# Altium schematic sheet Sheet2.SchDoc
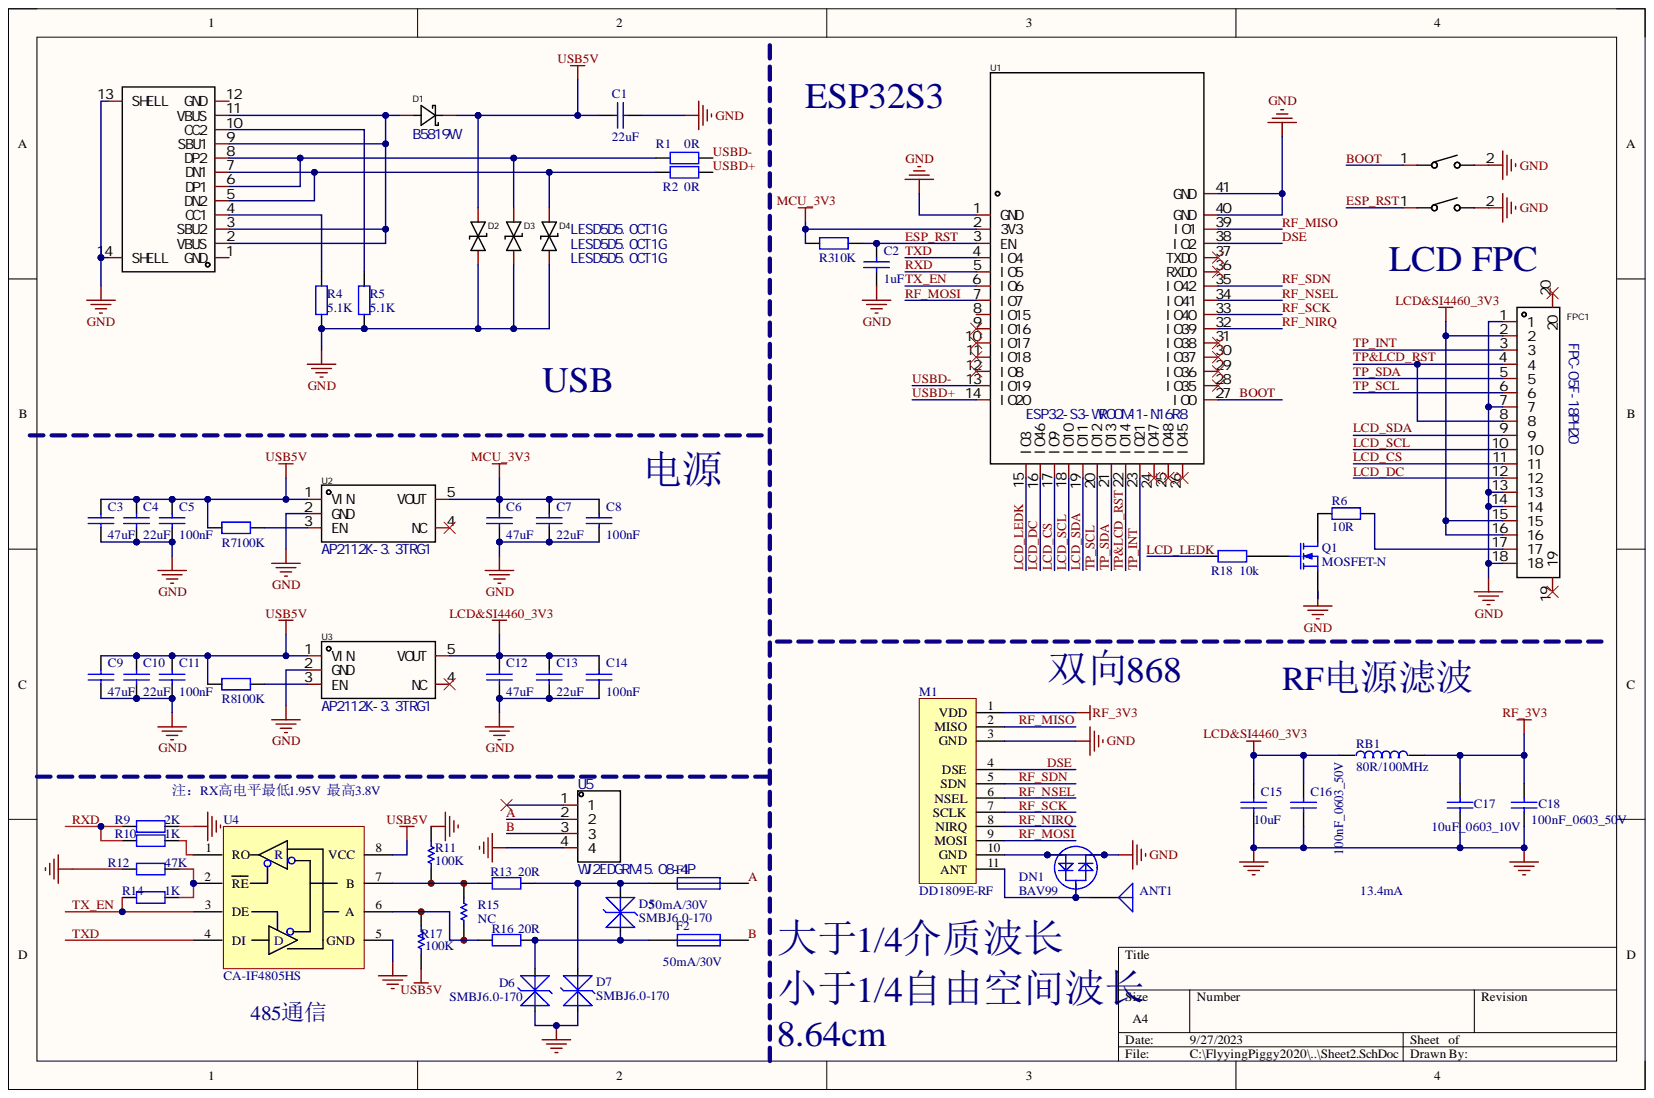
[diagram p0: Sheet2.SchDoc]

## Parts
ANT1  (no value)  [18AWG]
C1  22uF  [0603_C]
C2  1uF  [0603_C]
C3  47uF  [0603_C]
C4  22uF  [0603_C]
C5  100nF  [0603_C]
C6  47uF  [0603_C]
C7  22uF  [0603_C]
C8  100nF  [0603_C]
C9  47uF  [0603_C]
C10  22uF  [0603_C]
C11  100nF  [0603_C]
C12  47uF  [0603_C]
C13  22uF  [0603_C]
C14  100nF  [0603_C]
C15  10uF_0603_10V  value 10uF  [0603_C]
C16  100nF_0603_50V  [0603_C]
C17  10uF_0603_10V  [0603_C]
C18  100nF_0603_50V  [0603_C]
D1  B5819W  [SOD-123F_L2.7-W1.6-LS3.8-RD-1]
D2  LESD5D5.0CT1G  [SOD-523_L1.2-W0.8-LS1.6-BI]
D3  LESD5D5.0CT1G  [SOD-523_L1.2-W0.8-LS1.6-BI]
D4  LESD5D5.0CT1G  [SOD-523_L1.2-W0.8-LS1.6-BI]
D5  SMBJ6.0-170  [SMB(DO-214AA) - TVS]
D6  SMBJ6.0-170  [SMB(DO-214AA) - TVS]
D7  SMBJ6.0-170  [SMB(DO-214AA) - TVS]
DN1  BAV99  [SOT-23(SOT-23-3)]
F1  贴片自恢复保险丝 1812 0.1A 60V  [1206_R]  (value not drawn)
F2  贴片自恢复保险丝 1812 0.1A 60V  [1206_R]  (value not drawn)
FPC1  FPC-05F-18PH20  [FPC-SMD_18P-P0.50_FPC-05F-18PH20]
M1  DD1809E-RF  [DD1809(E)-RF-平]
Q1  MOSFET-N  [SOT-23(SOT-23-3)]
R1  0R  [0603_R]
R2  0R  [0603_R]
R3  value 10K  [0603_R]  (hidden comment: 0R)
R4  5.1K  [0603_R]
R5  5.1K  [0603_R]
R6  value 10R  [0603_R]  (hidden comment: 0R)
R7  100K  [0603_R]
R8  100K  [0603_R]
R9  2K  [0603_R]
R10  1K  [0603_R]
R11  100K  [0603_R]
R12  47K  [0603_R]
R13  20R  [0603_R]
R14  1K  [0603_R]
R15  NC  [0603_R]
R16  20R  [0603_R]
R17  100K  [0603_R]
R18  value 10k  [0603_R]  (hidden comment: 0R)
RB1  value 80R/100MHz  [0603_R]  (hidden comment: 80R/100MHz_0603)
SW1  TS-1088-AR02016  [SW-SMD_L3.9-W3.0-P4.45]  (value not drawn)
SW2  TS-1088-AR02016  [SW-SMD_L3.9-W3.0-P4.45]  (value not drawn)
U1  ESP32-S3-WROOM-1-N16R8  [WIRELM-SMD_ESP32-S3-WROOM-1]
U2  AP2112K-3.3TRG1  [SOT-25-5_L2.9-W1.6-P0.95-LS2.8-BL]
U3  AP2112K-3.3TRG1  [SOT-25-5_L2.9-W1.6-P0.95-LS2.8-BL]
U4  CA-IF4805HS  [SOP8]
U5  WJ2EDGRM-5.08-4P  [CONN-TH_4P-P5.08_WJ2EDGRM-5.08-4P-14-00A]
USB1  TYPE-C 16PIN 2MD(073)  [USB-C-SMD_TYPE-C-6PIN-2MD-073]  (value not drawn)

## Nets
GND: C1.2 C2.1 C3.1 C4.1 C5.1 C6.1 C7.1 C8.1 C9.1 C10.1 C11.1 C12.1 C13.1 C14.1 C15.2 C16.1 C17.1 C18.1 D2.1 D3.1 D4.1 D6.1 D7.1 DN1.1 DN1.2 FPC1.1 FPC1.7 FPC1.13 FPC1.14 FPC1.18 M1.3 M1.10 Q1.2 R4.1 R5.1 R9.1 R11.2 R12.1 SW1.2 SW2.2 U1.1 U1.40 U1.41 U2.2 U3.2 U4.5 U5.4 USB1.13 USB1.14
LCD&SI4460_3V3: C12.2 C13.2 C14.2 C15.1 C16.2 FPC1.2 FPC1.15 FPC1.16 RB1.1 U3.5
MCU_3V3: C6.2 C7.2 C8.2 R3.1 U1.2 U2.5
RF_3V3: C17.2 C18.2 M1.1 RB1.2
USB5V: C1.1 C3.2 C4.2 C5.2 C9.2 C10.2 C11.2 D1.1 D2.2 R7.1 R8.1 R17.1 U2.1 U3.1 U4.8
A: F1.2 U5.2
B: F2.2 U5.3
BOOT: SW1.1 U1.27
DSE: M1.4 U1.38
ESP_RST: C2.2 R3.2 SW2.1 U1.3
LCD_CS: FPC1.11 U1.17
LCD_DC: FPC1.12 U1.16
LCD_LEDK: R18.1 U1.15
LCD_SCL: FPC1.10 U1.18
LCD_SDA: FPC1.9 U1.19
RF_MISO: M1.2 U1.39
RF_MOSI: M1.9 U1.7
RF_NIRQ: M1.8 U1.32
RF_NSEL: M1.6 U1.34
RF_SCK: M1.7 U1.33
RF_SDN: M1.5 U1.35
RXD: R9.2 R10.1 U1.5
TP&LCD_RST: FPC1.4 FPC1.8 U1.22
TP_INT: FPC1.3 U1.23
TP_SCL: FPC1.6 U1.20
TP_SDA: FPC1.5 U1.21
TX_EN: R14.1 U1.6 U4.3
TXD: U1.4 U4.4
USBD+: R2.2 U1.14
USBD-: R1.2 U1.13
NetANT1_1: ANT1.1 DN1.3 M1.11
NetD1_2: D1.2 USB1.2 USB1.3 USB1.9 USB1.11
NetD3_2: D3.2 R1.1 USB1.6 USB1.8
NetD4_2: D4.2 R2.1 USB1.5 USB1.7
NetD5_1: D5.1 D6.2 F2.1 R16.1
NetD5_2: D5.2 D7.2 F1.1 R13.1
NetFPC1_17: FPC1.17 R6.2
NetQ1_1: Q1.1 R18.2
NetQ1_3: Q1.3 R6.1
NetR4_2: R4.2 USB1.4
NetR5_2: R5.2 USB1.10
NetR7_2: R7.2 U2.3
NetR8_2: R8.2 U3.3
NetR10_2: R10.2 U4.1
NetR11_1: R11.1 R13.2 R15.2 U4.7
NetR12_2: R12.2 R14.2 U4.2
NetR15_1: R15.1 R16.2 R17.2 U4.6

Not connected: FPC1 19 20; U1 8 9 10 11 12 24 25 26 28 29 30 31 36 37; U2 4; U3 4; U5 1; USB1 1 12

## Library data of the parts
ANT1 = Antenna: Generic Antenna; Component Kind: Standard; LatestRevisionDate: 17-Jul-2002; LatestRevisionNote: Re-released for DXP Platform.; Library Name: 原理图库.SchLib; PackageReference: PIN1; Published: 24-Mar-1999; Publisher: Altium Limited
C1-C14 = CAP_SMT: 贴片电容; Component Kind: Standard; Library Name: Schlib1.SchLib
C15 = 10uF_0603_10V: 瓷片电容 X5R-0603-10V-10uF/C-±10%; 品号: 3100034033; 封装: 0603; Component Kind: Standard; 品名: 瓷片电容	X5R-0603-10V-10uF/C-±10%; Library Name: mine.SchLib
C16 C18 = 100nF_0603_50V: 电容 瓷片 X7R-0603-50V-0.1uF/C-±10%; VALUE: 100nF; 品号: 3100022700; 封装: 0603; Component Kind: Standard; 品名: 电容 瓷片 X7R-0603-50V-0.1uF/C-±10%; Library Name: mine.SchLib
C17 = 10uF_0603_10V: 瓷片电容 X5R-0603-10V-10uF/C-±10%; VALUE: 10uF; 品号: 3100034033; 封装: 0603; Component Kind: Standard; 品名: 瓷片电容	X5R-0603-10V-10uF/C-±10%; Library Name: mine.SchLib
D1 = B5819W: Manufacturer: GOODWORK(固得沃克); Add into BOM: yes; Average Rectified Current (Io): 1A; Forward Voltage (Vf@If): 580mV@1A; Reverse Leakage Current (Ir): 50uA@40V; Reverse Voltage (Vr): 40V; Symbol: B5819W_C2943878; Component Kind: Standard; Convert to PCB: yes; Datasheet: https://atta.szlcsc.com/upload/public/pdf/source/20220114/81042131F39C8520B6390246D7392C1A.pdf; Device: B5819W; Diode Configuration: -; JLCPCB Part Class: Extended Part; LCSC Part Name: 40V 1A 580mV@1A; Library Name: Schlib1.SchLib; Manufacturer Part: B5819W; Name: B5819W; Supplier: LCSC; Supplier Footprint: SOD-123; Supplier Part: C2943878
D2-D4 = LESD5D5.0CT1G: Manufacturer: LRC(乐山无线电); Add into BOM: yes; Breakdown Voltage (VBR): 5.6V; Clamping Voltage@Ipp: 18.6V; Peak Pulse Current(Ipp)@8/20us: 9.4A; Polarity: 双向; Reverse Stand-Off Voltage (Vrwm): 5V; Component Kind: Standard; Convert to PCB: yes; Datasheet: https://atta.szlcsc.com/upload/public/pdf/source/20190807/C383211_633DF4B4E2C161403226E5235FE33A1C.pdf; Device: LESD5D5.0CT1G; JLCPCB Part Class: Extended Part; LCSC Part Name: 双向ESD; Library Name: Schlib1.SchLib; Manufacturer Part: LESD5D5.0CT1G; Name: LESD5D5.0CT1G; Supplier: LCSC; Supplier Footprint: SOD-523; Supplier Part: C383211; Symbol: LESD5D5.0CT1G
D5-D7 = TVS: Component Kind: Standard; Description: TVS; Library Name: Schlib1.SchLib
DN1 = BAV99: 品号: 3101002543; 品名: 开关二极管 BAV99 SOT-23; 规格: SMD【贴片】; Component Kind: Standard; Library Name: Ruan.SchLib
F1 F2 = Fuse 1: Fuse; : 50mA/30V; Component Kind: Standard; LatestRevisionDate: 17-Jul-2002; LatestRevisionNote: Re-released for DXP Platform.; PackageReference: PIN-W2/E2.8; Published: 8-Jun-2000; Publisher: Altium Limited
FPC1 = FPC-05F-18PH20: Manufacturer: XUNPU(讯普); Add into BOM: yes; FFC, FCB Thickness: 0.3mm; Locking Feature: 翻盖式; Number of Contacts: 18P; Pitch: 0.5mm; Component Kind: Standard; Contact Type: 下接; Convert to PCB: yes; Datasheet: https://atta.szlcsc.com/upload/public/pdf/source/20210922/C2856802_2A845D1961F33AEC1ED22CECB292335F.pdf; Device: FPC-05F-18PH20; Height Above Board: 2mm; JLCPCB Part Class: Extended Part; LCSC Part Name: 0.5mm P数:18P 翻盖式 下接; Library Name: Schlib1.SchLib; Manufacturer Part: FPC-05F-18PH20; Mounting Type: 卧贴; Name: FPC-05F-18PH20; Operating Temperature Range: -25℃~+85℃; PCB3D: FPC-SMD_18P-P0.50_FPC-05F-18PH20; Supplier: LCSC; Supplier Footprint: SMD,P=0.5mm; Supplier Part: C2856802; Symbol: FPC-05F-18PH20
M1 = DD1809E-RF: 平插; Component Kind: Standard; Library Name: Ruan.SchLib
Q1 = MOSFET-N: N-Channel MOSFET; Component Kind: Standard; Library Name: Schlib1.SchLib
R1-R8 R18 = RES: Resistor; Component Kind: Standard; LatestRevisionDate: 17-Jul-2002; LatestRevisionNote: Re-released for DXP Platform.; Library Name: Schlib1.SchLib; Published: 8-Jun-2000; Publisher: Altium Limited
  R1 R2: Value: 0R
  R4 R5: Value: 5.1K
  R7 R8: Value: 100K
R9 R10 R12 R14 = Res2: Resistor; Component Kind: Standard; LatestRevisionDate: 17-Jul-2002; LatestRevisionNote: Re-released for DXP Platform.; Published: 8-Jun-2000; Publisher: Altium Limited
  R9: Value: 2K
  R10 R14: Value: 1K
  R12: Value: 47K
R11 R17 = Res1: Resistor; Value: 10K; Component Kind: Standard; LatestRevisionDate: 17-Jul-2002; LatestRevisionNote: Re-released for DXP Platform.; PackageReference: AXIAL-0.3; Published: 8-Jun-2000; Publisher: Altium Limited
R13 R16 = Res2: Resistor; Value: 10K; Component Kind: Standard; LatestRevisionDate: 17-Jul-2002; LatestRevisionNote: Re-released for DXP Platform.; PackageReference: AXIAL-0.3; Published: 8-Jun-2000; Publisher: Altium Limited
R15 = Res1: Resistor; Component Kind: Standard; LatestRevisionDate: 17-Jul-2002; LatestRevisionNote: Re-released for DXP Platform.; PackageReference: AXIAL-0.3; Published: 8-Jun-2000; Value: NC; Publisher: Altium Limited
RB1 = 80R/100MHz_0603: 磁珠 80R/100MHZ 贴片0603; 品号: 3101000899; 封装: R0603; Component Kind: Standard; 品名: 磁珠 80R/100MHZ 贴片0603; Library Name: mine.SchLib
SW1 SW2 = KEY: Manufacturer: XUNPU(讯普); Actuator/Cap Color: 黑色; Actuator Style: 圆形按钮; Add into BOM: yes; Circuit: 单刀单掷; Contact Current: 50mA; Insulation Resistance: 100MΩ; Mechanical Life: 10万次; Operating Force: 160gf@±50gf; Pin Style: SMD接片; Strike Gundam: 否; Switch Height: 2mm; Switch Length: 4mm; Switch Width: 3mm; Voltage Rating (DC): 12V; Component Kind: Standard; Convert to PCB: yes; Datasheet: https://atta.szlcsc.com/upload/public/pdf/source/20200810/C720475_60D09DD6C54279ADDB2DC25100F1CC11.pdf; Device: TS-1088-AR02016; JLCPCB Part Class: Extended Part; LCSC Part Name: 4*3*2mm 立贴 轻触开关; Library Name: Schlib1.SchLib; Manufacturer Part: TS-1088-AR02016; Mounting Style: 立贴; Name: TS-1088-AR02016; Operating Temperature: -30℃~+80℃; Supplier: LCSC; Supplier Footprint: SMD; Supplier Part: C720477; Symbol: TS-1088-AR02016
U1 = ESP32-S3-WROOM-1-N16R8: Manufacturer: ESPRESSIF(乐鑫); Add into BOM: yes; Symbol: ESP32-S3-WROOM-1(N16R8); Component Kind: Standard; Convert to PCB: yes; Datasheet: https://atta.szlcsc.com/upload/public/pdf/source/20220705/4E799F39581577F766659887F738A439.pdf; Device: ESP32-S3-WROOM-1-N16R8; JLCPCB Part Class: Extended Part; LCSC Part Name: 通用型Wi-Fi+低功耗蓝牙MCU模组; Library Name: Schlib1.SchLib; Manufacturer Part: ESP32-S3-WROOM-1-N16R8; Name: ESP32-S3-WROOM-1-N16R8; Supplier: LCSC; Supplier Footprint: SMD,18x25.5mm; Supplier Part: C2913202
U2 U3 = AP2112K-3.3TRG1: Manufacturer: DIODES(美台); Add into BOM: yes; Dropout Voltage: 400mV@(600mA); Maximum Input Voltage: 6V; Number of Outputs: 1; Output Current: 600mA; Output Polarity: 正; Output Type: 固定; Output Voltage: 3.3V; Power Supply Rejection Ratio (PSRR): 65dB@(100Hz,1kHz); Component Kind: Standard; Convert to PCB: yes; Datasheet: https://atta.szlcsc.com/upload/public/pdf/source/20171221/C51118_15138312998391195590.pdf; Device: AP2112K-3.3TRG1; JLCPCB Part Class: Extended Part; LCSC Part Name: AP2112K-3.3TRG1; Library Name: Schlib1.SchLib; Manufacturer Part: AP2112K-3.3TRG1; Name: AP2112K-3.3TRG1; Supplier: LCSC; Supplier Footprint: SOT-25-5; Supplier Part: C51118; Symbol: AP2112K-3.3TRG1
U4 = MAX485E: Component Kind: Standard; Description: MAX485E; Library Name: N IC-GRC.SCHLIB
U5 = WJ2EDGRM-5.08-4P: 连接器类型:板端/插座-闭口;间距:5.08mm;排数:1;每排PIN数:4;安装方式:弯针;颜色:绿色;固定方式:螺丝固定;; Manufacturer: KANGNEX(康奈克斯电气); Add into BOM: yes; fixed form: 螺丝固定; Number of PINs Per Row: 4; Pitch: 5.08mm; Symbol: WJ2EDGRM-5.08-4P-14-00A; Color: 绿色; Component Kind: Standard; Connector Type: 板端/插座-闭口; Convert to PCB: yes; Datasheet: https://atta.szlcsc.com/upload/public/pdf/source/20191225/C122715_5EDD850D23D79873C9DD0610CAA761C1.pdf; Device: WJ2EDGRM-5.08-4P; JLCPCB Part Class: 扩展库; LCSC Part Name: 板端/插座-闭口 5.08mm 排数:1 每排P数:4 弯针 螺丝固定; Library Name: Schlib1.SchLib; Manufacturer Part: WJ2EDGRM-5.08-4P; Mounting Style: 弯针; Name: WJ2EDGRM-5.08-4P; Number of Rows: 1; Supplier: LCSC; Supplier Footprint: P=5.08mm; Supplier Part: C122715
USB1 = TYPE-C 16PIN 2MD(073): Manufacturer: SHOU HAN(首韩); Add into BOM: yes; Current Rating - Power (Max): 5A; Gender: 母座; Number of Contacts: 16; Number of Ports: 1; Component Kind: Standard; Connector Type: Type-C; Convert to PCB: yes; Device: TYPE-C 16PIN 2MD(073); JLCPCB Part Class: Extended Part; LCSC Part Name: TYPE-C母座 16P 4脚插件带定位柱 不锈钢外壳 2次模顶; Library Name: Schlib1.SchLib; Manufacturer Part: TYPE-C 16PIN 2MD(073); Mounting Style: 卧贴; Name: TYPE-C 16PIN 2MD(073); Operating Temperature Range: -25℃~+85℃; Standard: -; Supplier: LCSC; Supplier Footprint: SMD; Supplier Part: C2765186; Symbol: TYPE-C 16PIN 2MD(073)
